# Altium schematic sheet Arduino_Dev.SchDoc(Arduino_Dev)
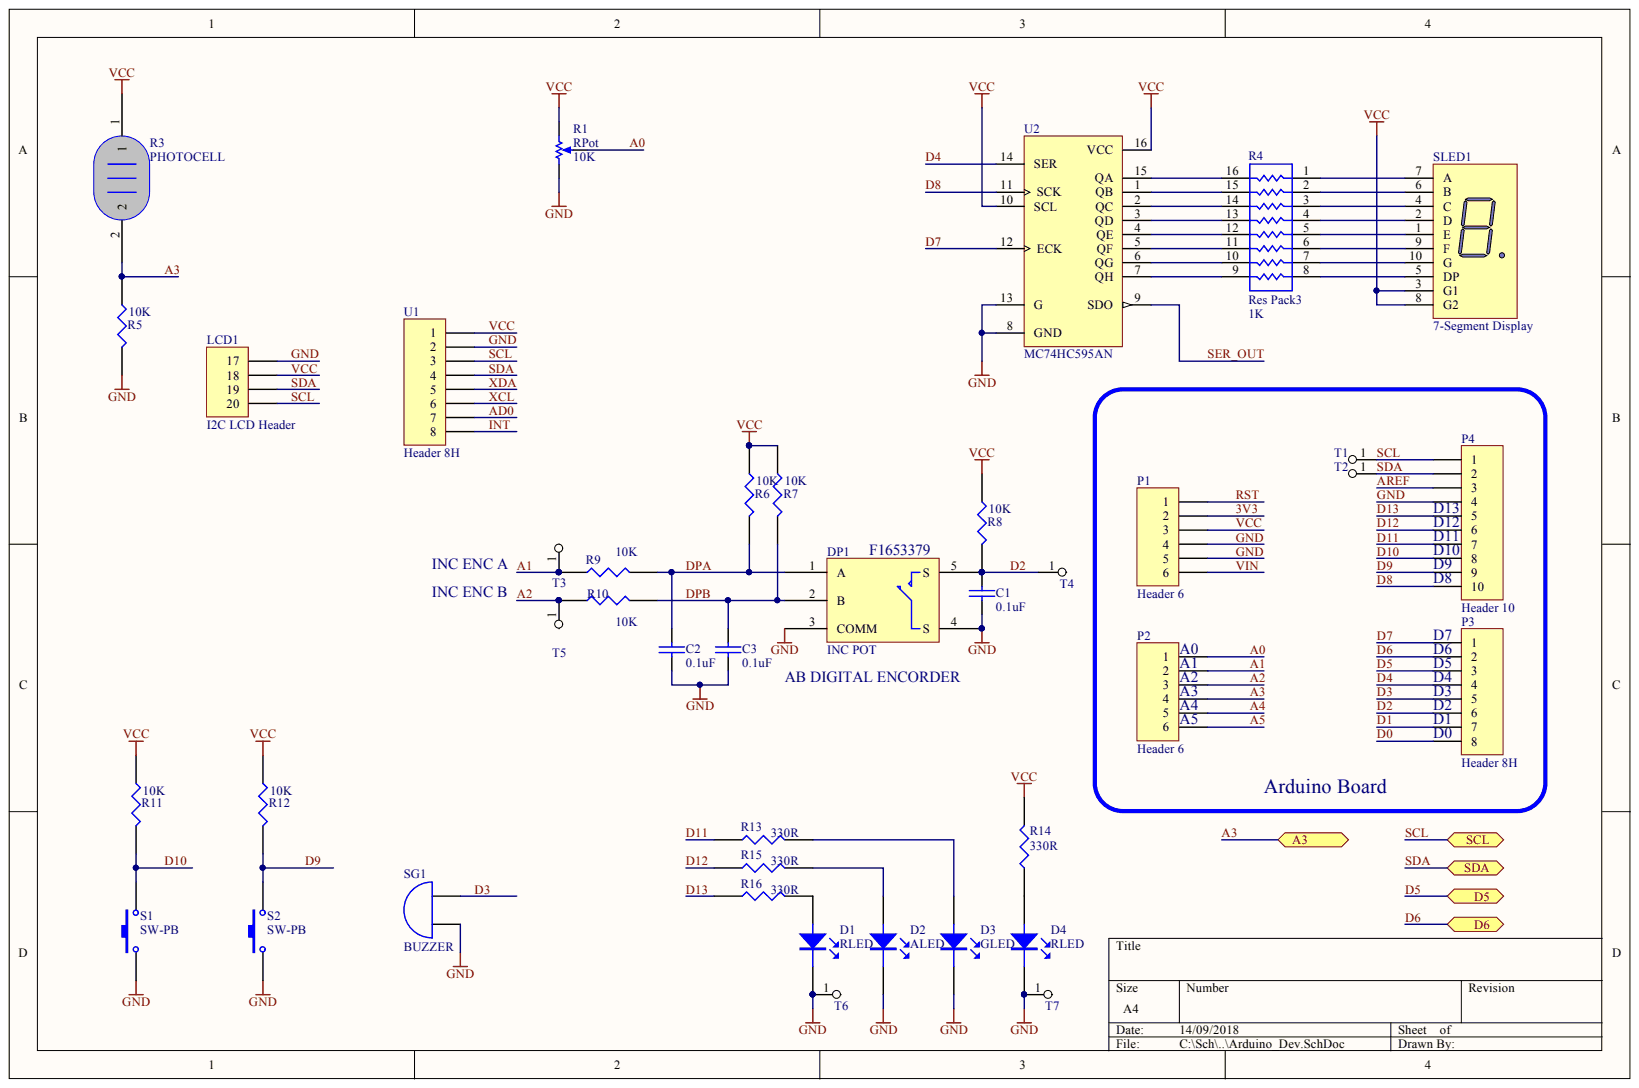
[diagram p0: Arduino_Dev.SchDoc]

## Parts
C1  0.1uF  [DECAP]
C2  0.1uF  [DECAP]
C3  0.1uF  [DECAP]
D1  RLED  [LED]
D2  ALED  [LED]
D3  GLED  [LED]
D4  RLED  [LED]
DP1  INC POT  [PEC11]
LCD1  I2C LCD Header  [LCD_I2C_Slotted]
P1  Header 6  [HDR1X6]
P2  Header 6  [HDR1X6]
P3  Header 8H  [HDR1X8]
P4  Header 10  [HDR1X10]
R1  RPot  value 10K  [POT_VERT]
R3  PHOTOCELL  [LED]
R4  Res Pack3  value 1K  [DIP16]
R5  10K  [RES0.4]
R6  10K  [RES0.4]
R7  10K  [RES0.4]
R8  10K  [RES0.4]
R9  10K  [RES0.4]
R10  10K  [RES0.4]
R11  10K  [RES0.4]
R12  10K  [RES0.4]
R13  330R  [RES0.4]
R14  330R  [RES0.4]
R15  330R  [RES0.4]
R16  330R  [RES0.4]
S1  SW-PB  [SPNOC]
S2  SW-PB  [SPNOC]
SG1  BUZZER  [PIEZO BUZZER]
SLED1  7-Segment Display  [H]
T1  TP  [TP]  (value not drawn)
T2  TP  [TP]  (value not drawn)
T3  TP  [TP]  (value not drawn)
T4  TP  [TP]  (value not drawn)
T5  TP  [TP]  (value not drawn)
T6  TP  [TP]  (value not drawn)
T7  TP  [TP]  (value not drawn)
U1  Header 8H  [ITG_MPU]
U2  MC74HC595AN  [DIP16]

## Ports
A3, D5, D6, SCL, SDA

## Nets
3V3: P1.2
A0: P2.1 R1.3
A1: P2.2 R9.1 T3.1
A2: P2.3 R10.1 T5.1
A3: P2.4 R3.2 R5.1 ; port A3
A4: P2.5
A5: P2.6
AD0: U1.7
AREF: P4.3
D0: P3.8
D1: P3.7
D2: C1.2 DP1.5(S) P3.6 R8.2 T4.1
D3: P3.5 SG1.2
D4: P3.4 U2.14(SER)
D5: P3.3 ; port D5
D6: P3.2 ; port D6
D7: P3.1 U2.12(ECK)
D8: P4.10 U2.11(SCK)
D9: P4.9 R12.2 S2.2
D10: P4.8 R11.2 S1.2
D11: P4.7 R13.1
D12: P4.6 R15.1
D13: P4.5 R16.1
DPA: C2.2 DP1.1(A) R6.2 R9.2
DPB: C3.2 DP1.2(B) R7.2 R10.2
GND: C1.1 C2.1 C3.1 D1.1 D2.1 D3.1 D4.1 DP1.3(COMM) DP1.4(S) LCD1.17 P1.4 P1.5 P4.4 R1.1 R5.2 S1.1 S2.1 SG1.1 T6.1 T7.1 U1.2 U2.8(GND) U2.13(G)
INT: U1.8
RST: P1.1
SCL: LCD1.20 P4.1 T1.1 U1.3 ; port SCL
SDA: LCD1.19 P4.2 T2.1 U1.4 ; port SDA
SER_OUT: U2.9(SDO)
VCC: LCD1.18 P1.3 R1.2 R3.1 R6.1 R7.1 R8.1 R11.1 R12.1 R14.2 SLED1.3(G1) SLED1.8(G2) U1.1 U2.10(SCL) U2.16(VCC)
VIN: P1.6
XCL: U1.6
XDA: U1.5
NetD1_2: D1.2 R16.2
NetD2_2: D2.2 R15.2
NetD3_2: D3.2 R13.2
NetD4_2: D4.2 R14.1
NetR4_1: R4.1 SLED1.7(A)
NetR4_2: R4.2 SLED1.6(B)
NetR4_3: R4.3 SLED1.4(C)
NetR4_4: R4.4 SLED1.2(D)
NetR4_5: R4.5 SLED1.1(E)
NetR4_6: R4.6 SLED1.9(F)
NetR4_7: R4.7 SLED1.10(G)
NetR4_8: R4.8 SLED1.5(DP)
NetR4_9: R4.9 U2.7(QH)
NetR4_10: R4.10 U2.6(QG)
NetR4_11: R4.11 U2.5(QF)
NetR4_12: R4.12 U2.4(QE)
NetR4_13: R4.13 U2.3(QD)
NetR4_14: R4.14 U2.2(QC)
NetR4_15: R4.15 U2.1(QB)
NetR4_16: R4.16 U2.15(QA)

## Library data of the parts
C1-C3 = CAP: Component Kind: Standard; Library Name: Ethernet.SchLib
D1-D4 = LED: Component Kind: Standard
DP1 = INC ENCODER: Component Kind: Standard; Library Name: SchemaicComponents.SchLib
LCD1 = Header 4: Header, 4-Pin; Component Kind: Standard; LatestRevisionDate: 17-Jul-2002; LatestRevisionNote: Re-released for DXP Platform.; Library Name: Miscellaneous Connectors.IntLib; Signal Integrity: Connector; Publisher: Altium Limited
P1 P2 = Header 6: Header, 6-Pin; Component Kind: Standard; LatestRevisionDate: 17-Jul-2002; LatestRevisionNote: Re-released for DXP Platform.; Library Name: Miscellaneous Connectors.IntLib; Signal Integrity: Connector; Publisher: Altium Limited
P3 U1 = Header 8H: Header, 8-Pin, Right Angle; Component Kind: Standard; LatestRevisionDate: 17-Jul-2002; LatestRevisionNote: Re-released for DXP Platform.; Library Name: Miscellaneous Connectors.IntLib; Signal Integrity: Connector; Publisher: Altium Limited
P4 = Header 10: Header, 10-Pin; Component Kind: Standard; LatestRevisionDate: 17-Jul-2002; LatestRevisionNote: Re-released for DXP Platform.; Library Name: Miscellaneous Connectors.IntLib; Signal Integrity: Connector; Publisher: Altium Limited
R1 = RPot: Potentiometer; Set Position: 0.5; Sim Note: Enter the Resistance value and the set point into parameter fields.; Component Kind: Standard; LatestRevisionDate: 17-Jul-2002; LatestRevisionNote: Re-released for DXP Platform.; Library Name: Miscellaneous Devices.IntLib; PackageReference: VR5; Published: 8-Jun-2000; Simulation: POT; Publisher: Altium Limited
R4 = Res Pack3: Isolated Resistor Network; Component Kind: Standard; LatestRevisionDate: 17-Jul-2002; LatestRevisionNote: Re-released for DXP Platform.; Library Name: Miscellaneous Devices.IntLib; PackageReference: SO-16; Published: 24-Mar-1999; Simulation: respack_8; Publisher: Altium Limited
R5-R16 = RES1: Component Kind: Standard; Library Name: Ethernet.SchLib
S1 S2 = SW-PB: Switch; Component Kind: Standard; LatestRevisionDate: 17-Jul-2002; LatestRevisionNote: Re-released for DXP Platform.; Library Name: Miscellaneous Devices.IntLib; PackageReference: SPST-2; Published: 24-Mar-1999; Publisher: Altium Limited
SG1 = Bell: Electrical Bell; Component Kind: Standard; LatestRevisionDate: 17-Jul-2002; LatestRevisionNote: Re-released for DXP Platform.; Library Name: Miscellaneous Devices.IntLib; PackageReference: PIN2; Published: 24-Mar-1999; Publisher: Altium Limited
SLED1 = Dpy Blue-CA: F1142438; MinimumIntensity: 2020 ucd; Note: IF = 10 mA; Colour: Blue; Component Kind: Standard; ComponentLink1Description: Manufacturer Link; ComponentLink1URL: http://www.agilent.com/; DatasheetDocument: 24-Sep-2002; LatestRevisionDate: 17-Dec-2003; LatestRevisionNote: Schematic revised, PCB Footprint replaced.; Library Name: IO.SCHLIB; PackageDocument: 24-Sep-2002; PackageReference: H; Published: 8-Jun-2000; Simulation: HDSP_501B; Publisher: Altium Limited
U2 = MC74HC595AN: 8-Bit Serial-Input/Serial or Prallel-Output Shift Register with Latched 3-State Outputs; Code_JEDEC: MS-001-BB; Component Kind: Standard; ComponentLink1Description: Manufacturer Link; ComponentLink1URL: http://www.onsemi.com/; ComponentLink2Description: Datasheet; ComponentLink2URL: http://www.onsemi.com/pub/Collateral/MC74HC595A-D.PDF; DatasheetVersion: Mar-2000; Library Name: IO.SCHLIB; PackageDescription: DIP; 16 Leads; Row Spacing 7.62 mm; Pitch 2.54 mm; PackageReference: 648-08; PackageVersion: Apr-2000; Published: 15-Apr-2002; Signal Integrity: MC74HC595AN; Publisher: Altium Limited
R3 = PHOTOCELL: Component Kind: Standard; Library Name: IO.SCHLIB
T1-T7 = TP: Component Kind: Standard; Library Name: Student.SchLib
